# Altium schematic sheet obc_main_3.0.SchDoc
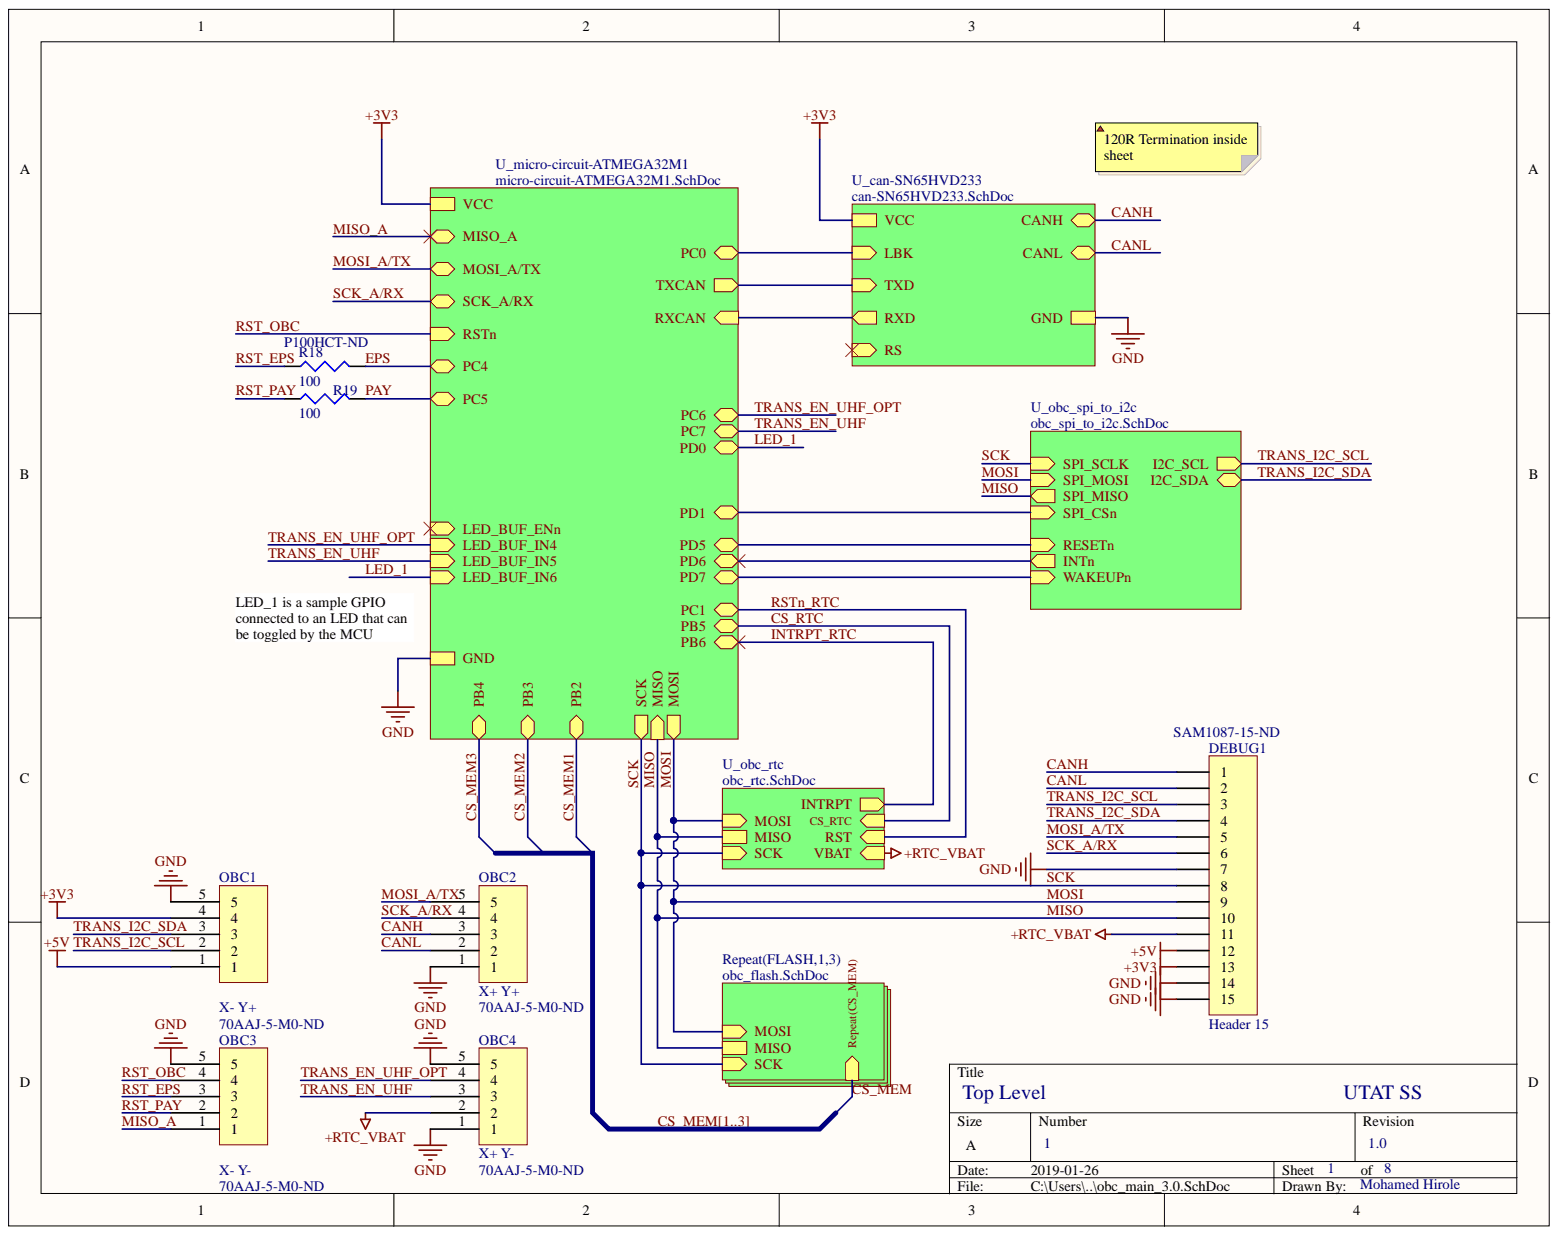
[diagram p0: obc_main_3.0.SchDoc]

## Parts
DEBUG1  Header 15  Supplier Part Number 1: SAM1087-15-ND  [HDR1X15]
OBC1  X- Y+  [70AAJ-5-M0]
OBC2  X+ Y+  Supplier Part Number 1: 70AAJ-5-M0-ND  [70AAJ-5-M0]
OBC3  X- Y-  Supplier Part Number 1: 70AAJ-5-M0-ND  [70AAJ-5-M0]
OBC4  X+ Y-  Supplier Part Number 1: 70AAJ-5-M0-ND  [70AAJ-5-M0]
R18  100  Supplier Part Number 1: P100HCT-ND  [J1-0603]
R19  100  [J1-0603]

## Nets
+3V3: DEBUG1.13 OBC1.4
+5V: DEBUG1.12 OBC1.1
+RTC_VBAT: DEBUG1.11 OBC4.2
GND: DEBUG1.7 DEBUG1.14 DEBUG1.15 OBC1.5 OBC2.1 OBC3.5 OBC4.1 OBC4.5
CANH: DEBUG1.1 OBC2.3
CANL: DEBUG1.2 OBC2.2
EPS: R18.2
MISO: DEBUG1.10
MISO_A: OBC3.1
MOSI: DEBUG1.9
MOSI_A/TX: DEBUG1.5 OBC2.5
PAY: R19.2
RST_EPS: OBC3.3 R18.1
RST_OBC: OBC3.4
RST_PAY: OBC3.2 R19.1
SCK: DEBUG1.8
SCK_A/RX: DEBUG1.6 OBC2.4
TRANS_EN_UHF: OBC4.3
TRANS_EN_UHF_OPT: OBC4.4
TRANS_I2C_SCL: DEBUG1.3 OBC1.2
TRANS_I2C_SDA: DEBUG1.4 OBC1.3
CS_MEM1:  [also labelled CS_MEM]
CS_MEM2:  [also labelled CS_MEM]
CS_MEM3:  [also labelled CS_MEM]
CS_RTC:
INTRPT_RTC:
LED_1:
N00015:
N00016:
N00017:
N00018:
N00019:
N00020:
N00021:
N00022:
N00023:
RSTn_RTC:

## Library data of the parts
DEBUG1 = Header 15: Header, 15-Pin; Component Kind: Standard; LatestRevisionDate: 17-Jul-2002; LatestRevisionNote: Re-released for DXP Platform.; Library Name: Miscellaneous Connectors.IntLib; Signal Integrity: Connector; Supplier 1: Digi-Key; Publisher: Altium Limited
OBC1-OBC4 = 70AAJ-5-M0: Component Kind: Standard; Library Name: CONNs..SchLib; Supplier 1: Digi-Key
  OBC1: Supplier Part Number 1: 70AAJ-5-M0-ND
R18 R19 = Res3: Resistor; Component Kind: Standard; LatestRevisionDate: 29-May-2009; LatestRevisionNote: IPC-7351 Footprint Added.; Library Name: Miscellaneous Devices.IntLib; PackageDescription: Chip Resistor; PackageReference: J1-0603; Published: 8-Jun-2000; Signal Integrity: Res; Simulation: RESISTOR; Supplier 1: Digi-Key; Value: 100; Publisher: Altium Limited
  R19: Supplier Part Number 1: P100HCT-ND
